# Altium schematic sheet ReboostV2_toplevel.SchDoc
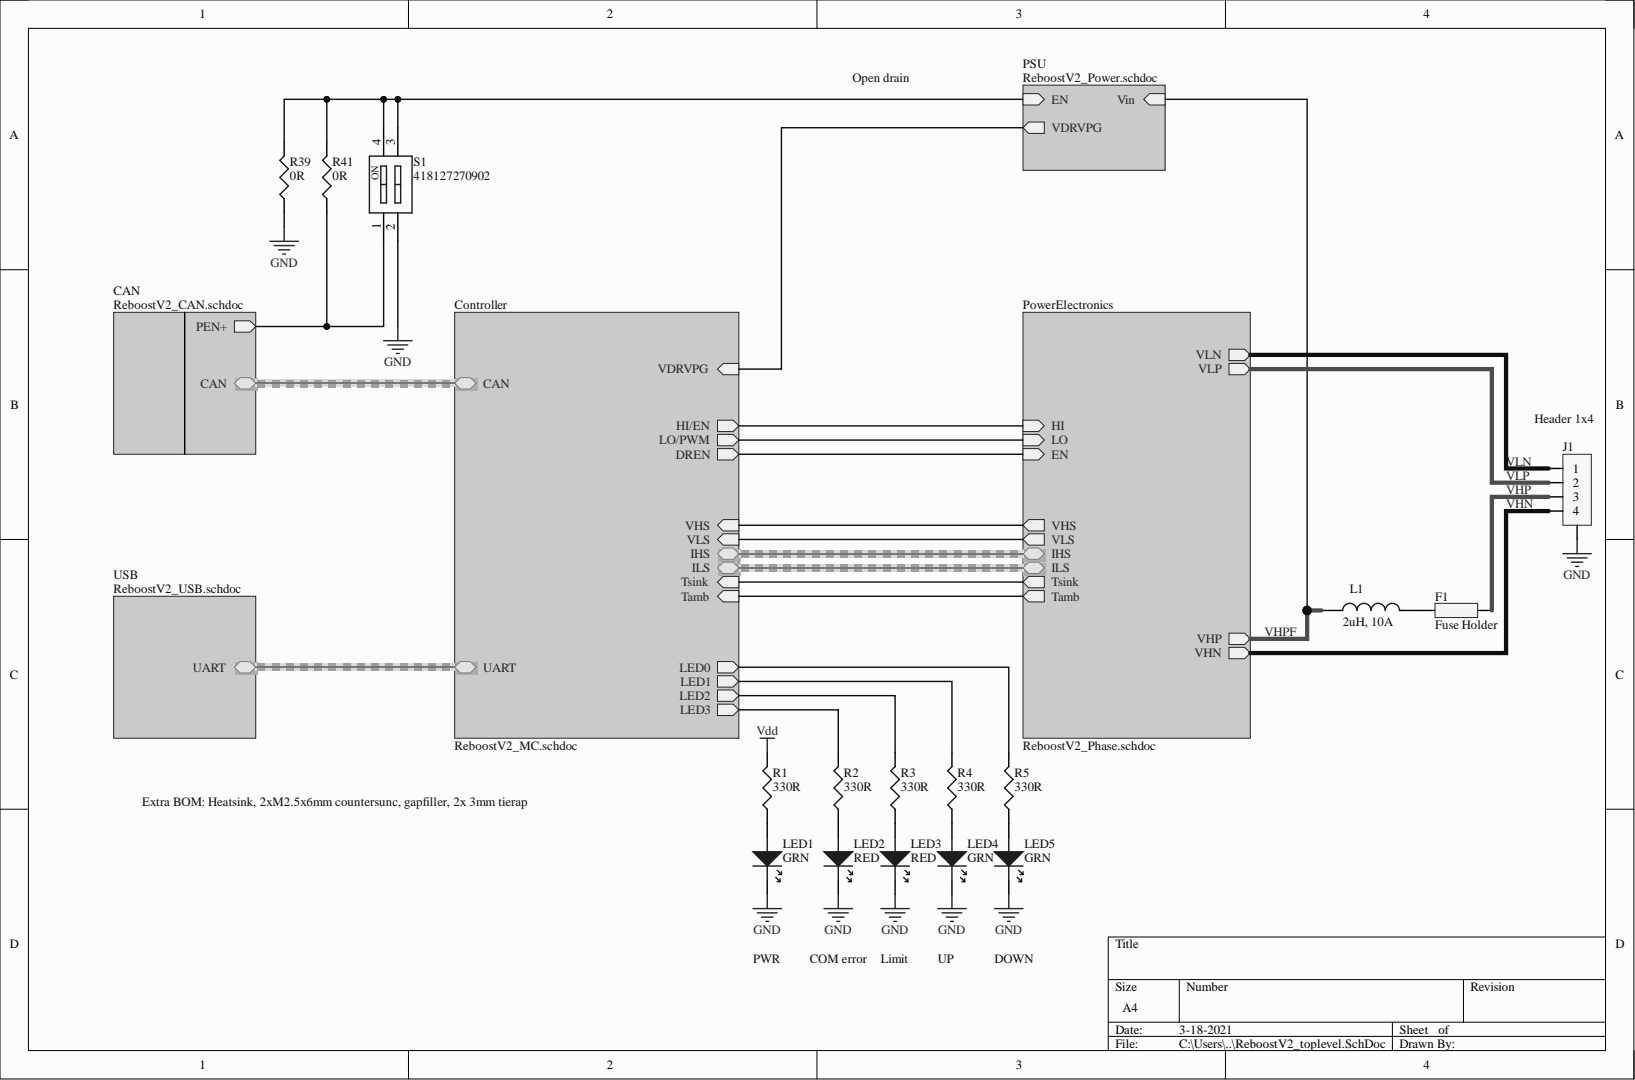
[diagram p0: ReboostV2_toplevel.SchDoc]

## Parts
F1  Fuse Holder  [Fuse5x20TH]
J1  Header 1x4  [PC 4/ 4-G-7,62]
L1  2uH, 10A  Rated Current: 10A  [744710210]
LED1  GRN  [WL-SMRW_1206 cylindrical_Reverse use]
LED2  RED  [WL-SMRW_1206 cylindrical_Reverse use]
LED3  RED  [WL-SMRW_1206 cylindrical_Reverse use]
LED4  GRN  [WL-SMRW_1206 cylindrical_Reverse use]
LED5  GRN  [WL-SMRW_1206 cylindrical_Reverse use]
R1  330R  [0603]
R2  330R  [0603]
R3  330R  [0603]
R4  330R  [0603]
R5  330R  [0603]
R39  0R  [0603]
R41  0R  [0603]
S1  418127270902  [418127270902]

## Nets
GND: J1.0 LED1.1 LED2.1 LED3.1 LED4.1 LED5.1 R39.1 S1.2
Vdd: R1.2
VHN: J1.4
VHP: F1.2 J1.3
VHPF: L1.1
VLN: J1.1
VLP: J1.2
NetF1_1: F1.1 L1.2
NetLED1_2: LED1.2 R1.1
NetLED2_2: LED2.2 R2.1
NetLED3_2: LED3.2 R3.1
NetLED4_2: LED4.2 R4.1
NetLED5_2: LED5.2 R5.1
NetR2_2: R2.2
NetR3_2: R3.2
NetR4_2: R4.2
NetR5_2: R5.2
NetR39_2: R39.2 R41.2 S1.3 S1.4
NetR41_1: R41.1 S1.1
CAN.RX:
CAN.TX:
DREN:
HI:
IHS.+:
IHS.-:
ILS.+:
ILS.-:
LO:
Tamb:
Tsink:
VDRVPG:
VHS:
VLS:

## Library data of the parts
F1 = 0031.8201: Fuse, Holder, 10A, 250V, T:-40°C +85°C; Brand: SCHURTER; Brand Number: 0031.8201; Component Kind: Standard; Library Name: AR_Misc.IntLib; Order Code Supplier: 1162740; Price: 0,43; Supplier: Farnell
J1 = PC 4/4-G-7,62_1: Header, Close Horizontal, 1x4, Male, 7.62mm, TH; Brand: PHOENIX CONTACT; Brand Number: PC 4/4-G-7,62; Component Kind: Standard; Library Name: AR_Connectors.IntLib; Order Code Supplier: 1792930; Pitch: 7.62mm; Price: 2,92; Supplier: Farnell
L1 = 744710210: WE-SD Rod Core Choke, 2uH, 10A; Manufacturer: Wurth Elektronik; DC Resistance: 3.8mOhm; Component Kind: Standard; ComponentLink1Description: Manufacturer; ComponentLink1URL: http://www.we-online.de; ComponentLink2Description: Datasheet; ComponentLink2URL: https://www.we-online.com/catalog/datasheet/744710210.pdf; Inductance: 2uH; Library Name: WE-SD (rev20a).IntLib; Manufacturer Part Number: 744710210; Mounting Technology: THT; Operating Temperature: -40 to +150degC; Packaging: Carton; Published Date: April 2020
LED1 LED4 LED5 = 156120GS75300: LED, Reverse Mount, Cylindrical, Green; Brand: Wurth; Brand Number: 156120GS75300; Component Kind: Standard; Library Name: AR_LEDs.IntLib; Order Code Supplier: 2322091; Price: 0,519; Supplier: Farnell
LED2 LED3 = 156120RS75300: LED, Reverse Mount, Cylindrical, Red; Brand: Wurth; Brand Number: 156120RS75300; Component Kind: Standard; Library Name: AR_LEDs.IntLib; Order Code Supplier: 2322094; Price: 0,519; Supplier: Farnell
R1-R5 = 330/0603/0063W/50V: Resistor, 330R, 1%, 0.063W, 50V, 100PPM, 0603; Brand: VISHAY DRALORIC; Brand Number: CRCW0603330RFKEA; Case Style: 0603; Component Kind: Standard; Library Name: AR_Resistors_SMD.IntLib; Order Code Supplier: 2122302; Power Rating: 0.063W; Price: 0,038; Resistance: 330R; Supplier: Farnell; Temperature Coefficient: ± 100PPM; Temperature Range: -55°C to +125°C; Voltage Rating: 50V
R39 R41 = 0/0603/0063W/50V: Resistor, 0R, 0.1%, 0.063W, 50V, 200PPM, 0603; Brand: VISHAY DRALORIC; Brand Number: CRCW06030000Z0EA; Case Style: 0603; Component Kind: Standard; Library Name: AR_Resistors_SMD.IntLib; Order Code Supplier: 2122112; Power Rating: 0.063W; Price: 0,043; Resistance: 0R; Supplier: Farnell; Temperature Coefficient: ± 200PPM; Temperature Range: -55°C to +125°C; Voltage Rating: 50V
S1 = 418127270902: WS-DITV Small Compact THT Dip Switch, Raised Actuator, pitch 2.54mm, 2p; Actuator Type: Raise Type; Circuit: SPST-NO; Operation Force: 600g; Pole Number: 2; Rated Current Non-Switching: 100mA; Rated Current Switching: 25mA; Rated Voltage Non-Switching: 50VDC; Rated Voltage Switching: 24VDC; Component Kind: Standard; ComponentLink1Description: Manufacturer; ComponentLink1URL: http://www.we-online.de; ComponentLink2Description: Datasheet; ComponentLink2URL: https://katalog.we-online.com/em/datasheet/418127270902.pdf; Contact Material: Copper Alloy; Contact Plating: Gold; Library Name: Altium_WS-DITV (rev19a).IntLib; Mounting Technology: THT; Operating Temperature: -40 to +85degC; Packaging: Tube; Part Number: 418127270902; Pitch: 2.54mm; Published Date: September 2019; Publisher: Wurth Elektronik
